# Altium schematic sheet farsterker.SchDoc
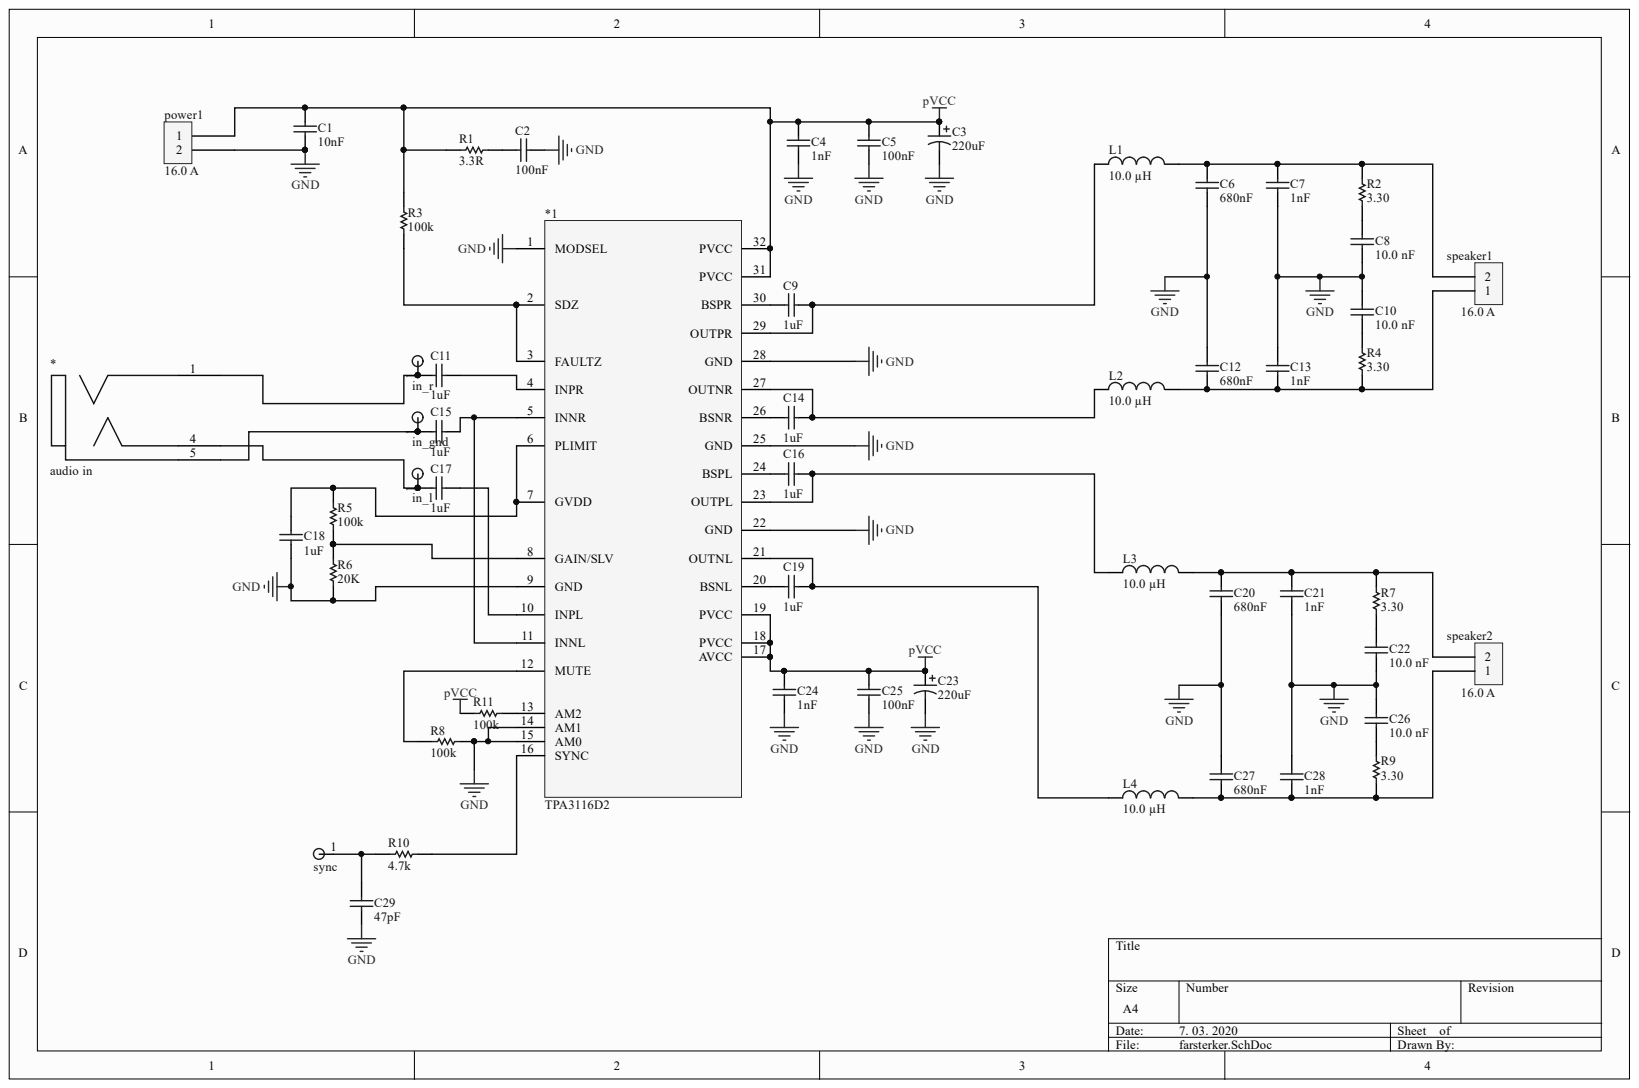
[diagram p0: farsterker.SchDoc]

## Parts
*  audio in  [PCB-329482-1]
*1  TPA3116D2  [PCB-1wxezy8eg5qk1v6v35wr-1]
C1  10nF  [CAPC2013X94X50NL20T25]
C2  100nF  [CAPC2013X94X50LL20T25]
C3  220uF  [EEUFC1V471]
C4  1nF  [CAPC2013X94X50NL20T25]
C5  100nF  [CAPC2013X140X50NL20T25]
C6  680nF  [CAPC2013X145X50NL20T25]
C7  1nF  [CAPC2013X94X50NL20T25]
C8  10.0 nF  [PCB-cftnmylkdkqegfcwasxi-1]
C9  1uF  [CAPC2013X94X50LL20T25]
C10  10.0 nF  [PCB-cftnmylkdkqegfcwasxi-1]
C11  1uF  [CAPC2013X94X50LL20T25]
C12  680nF  [CAPC2013X145X50NL20T25]
C13  1nF  [CAPC2013X94X50NL20T25]
C14  1uF  [CAPC2013X94X50LL20T25]
C15  1uF  [CAPC2013X94X50LL20T25]
C16  1uF  [CAPC2013X94X50LL20T25]
C17  1uF  [CAPC2013X94X50LL20T25]
C18  1uF  [CAPC2013X94X50LL20T25]
C19  1uF  [CAPC2013X94X50LL20T25]
C20  680nF  [CAPC2013X145X50NL20T25]
C21  1nF  [CAPC2013X94X50NL20T25]
C22  10.0 nF  [PCB-cftnmylkdkqegfcwasxi-1]
C23  220uF  [EEUFC1V471]
C24  1nF  [CAPC2013X94X50NL20T25]
C25  100nF  [CAPC2013X140X50NL20T25]
C26  10.0 nF  [PCB-cftnmylkdkqegfcwasxi-1]
C27  680nF  [CAPC2013X145X50NL20T25]
C28  1nF  [CAPC2013X94X50NL20T25]
C29  47pF  [CAPC2013X145X48LL20T23]
in_gnd  SYM-eb34223ee69c34f3-10  [PCB-a6m4wwq1w8vztsn322mx-1]  (value not drawn)
in_l  SYM-eb34223ee69c34f3-10  [PCB-a6m4wwq1w8vztsn322mx-1]  (value not drawn)
in_r  SYM-eb34223ee69c34f3-10  [PCB-a6m4wwq1w8vztsn322mx-1]  (value not drawn)
L1  10.0 µH  [PCB-qv8392ewdbk7uggmi3kr-1]
L2  10.0 µH  [PCB-qv8392ewdbk7uggmi3kr-1]
L3  10.0 µH  [PCB-qv8392ewdbk7uggmi3kr-1]
L4  10.0 µH  [PCB-qv8392ewdbk7uggmi3kr-1]
power1  16.0 A  [PCB-751604-1]
R1  3.3R  [RESC1608X55X30ML20T10]
R2  3.30  [PCB-61drmxuvl35l0xvdzxwi-1]
R3  100k  [RESC1608X55X30ML20T10]
R4  3.30  [PCB-61drmxuvl35l0xvdzxwi-1]
R5  100k  [RESC1608X55X30ML20T10]
R6  20K  [RESC1608X55X30ML20T10]
R7  3.30  [PCB-61drmxuvl35l0xvdzxwi-1]
R8  100k  [RESC1608X55X30ML20T10]
R9  3.30  [PCB-61drmxuvl35l0xvdzxwi-1]
R10  4.7k  [RESC1608X55X30ML20T10]
R11  100k  [RESC2013X60X35LL10T20]
speaker1  16.0 A  [PCB-751604-1]
speaker2  16.0 A  [PCB-751604-1]
sync  SYM-eb34223ee69c34f3-10  [PCB-a6m4wwq1w8vztsn322mx-1]  (value not drawn)

## Nets
GND: *1.1(MODSEL) *1.9(GND) *1.14(AM1) *1.15(AM0) *1.22(GND) *1.25(GND) *1.28(GND) C1.2 C2.2 C3.2 C4.1 C5.1 C6.1 C7.1 C8.1 C10.2 C12.2 C13.2 C18.1 C20.1 C21.1 C22.1 C23.2 C24.1 C25.1 C26.2 C27.2 C28.2 C29.1 power1.2 R6.2 R8.1
PVCC: *1.17(AVCC) *1.18(PVCC) *1.19(PVCC) *1.31(PVCC) *1.32(PVCC) C1.1 C3.1 C4.2 C5.2 C23.1 C24.2 C25.2 power1.1 R1.1 R3.2 R11.1
Net*1_2: *1.2(SDZ) *1.3(FAULTZ) R3.1
Net*1_4: *1.4(INPR) C11.2
Net*1_5: *1.5(INNR) *1.11(INNL) C15.2
Net*1_6: *1.6(PLIMIT) *1.7(GVDD) C18.2 R5.2
Net*1_8: *1.8(GAIN/SLV) R5.1 R6.1
Net*1_10: *1.10(INPL) C17.2
Net*1_12: *1.12(MUTE) R8.2
Net*1_13: *1.13(AM2) R11.2
Net*1_16: *1.16(SYNC) R10.2
Net*1_20: *1.20(BSNL) C19.1
Net*1_21: *1.21(OUTNL) C19.2 L4.1
Net*1_23: *1.23(OUTPL) C16.2 L3.1
Net*1_24: *1.24(BSPL) C16.1
Net*1_26: *1.26(BSNR) C14.1
Net*1_27: *1.27(OUTNR) C14.2 L2.1
Net*1_29: *1.29(OUTPR) C9.2 L1.1
Net*1_30: *1.30(BSPR) C9.1
Net*_1: *.1 C11.1 in_r.1
Net*_4: *.4 C17.1 in_l.1
Net*_5: *.5 C15.1 in_gnd.1
NetC2_1: C2.1 R1.2
NetC6_2: C6.2 C7.2 L1.2 R2.2 speaker1.2
NetC8_2: C8.2 R2.1
NetC10_1: C10.1 R4.2
NetC12_1: C12.1 C13.1 L2.2 R4.1 speaker1.1
NetC20_2: C20.2 C21.2 L3.2 R7.2 speaker2.2
NetC22_2: C22.2 R7.1
NetC26_1: C26.1 R9.2
NetC27_1: C27.1 C28.1 L4.2 R9.1 speaker2.1
NetC29_2: C29.2 R10.1 sync.1

## Library data of the parts
* = 35RASMT2BHNTRX: CONN JACK MONO 3.5MM SMD; Lead-Free Status: Lead Free; Component Kind: Standard; Library Name: Octopart library; Manufacturer Part Number: 35RASMT2BHNTRX; OctopartID: 3284fb872060ed43; Octopart Url: https://octopart.com/35rasmt2bhntrx-switchcraft-604034; RoHS: Compliant; Simulation: SIM-329482-1
*1 = TPA3116D2-1: footprint acording to data sheet with thermal pad; CustomComponentID: co6igaotrz3zpbi5ty22; Component Kind: Standard; Library Name: FavoritesLibrary
C1 = CMP-126154-2: CAP CER 39PF 100V 5% NP0 0805; Manufacturer Part Number: 08051A390JAT2A; Capacitance: 3.9e-11 F; Case/Package (SI): 2012; Dielectric Characteristic: C0G/NP0; Insulation Resistance: 1e+11 Ω; Lead-Free Status: Lead Free; Temperature Coefficient: 0.0 ppm/°C; Voltage Rating (DC): 100.0 V; Capacitance Tolerance: ±5%; Case/Package: 0805; Component Kind: Standard; Library Name: CommunityVault; Mounting Style: Surface Mount; Number of Pins: 2; OctopartID: dc1c17d34a2303db; Octopart Url: https://octopart.com/08051a390jat2a-avx+interconnect+%2F+elco-46510937; Packaging: Cut Tape (CT); REACH SVHC Compliance: No SVHC; RoHS: Compliant; Size-Length: 0.0020066 m; Size-Thickness: 0.0009398 m; Size-Width: 0.0012446 m
C2 C9 C11 C14-C19 = 08051A390JAT2A: CAP CER 39PF 100V 5% NP0 0805; Capacitance: 3.9e-11 F; Case/Package (SI): 2012; Dielectric Characteristic: C0G/NP0; Insulation Resistance: 1e+11 Ω; Lead-Free Status: Lead Free; Temperature Coefficient: 0.0 ppm/°C; Voltage Rating (DC): 100.0 V; Capacitance Tolerance: ±5%; Case/Package: 0805; Component Kind: Standard; Library Name: Octopart library; Manufacturer Part Number: 08051A390JAT2A; Mounting Style: Surface Mount; Number of Pins: 2; OctopartID: dc1c17d34a2303db; Octopart Url: https://octopart.com/08051a390jat2a-avx+interconnect+%2F+elco-46510937; Packaging: Cut Tape (CT); REACH SVHC Compliance: No SVHC; RoHS: Compliant; Size-Length: 0.0020066 m; Size-Thickness: 0.0009398 m; Size-Width: 0.0012446 m
C3 C23 = EEU-FC1V471: Cap Aluminum Lytic 470uF 35V 20% (10 X 20mm) Radial 5mm 1220mA 3000h 105Ø¢°C Automotive Bulk; Capacitance: 470.0 F; Dielectric Material: Aluminum Electrolytic; Lead-Free Status: Lead Free; Voltage Rating (DC): 35.0 V; Capacitance Tolerance: ±20%; Component Kind: Standard; Library Name: Octopart library; Lifecycle Status: Not Listed by Manufacturer; Manufacturer Part Number: EEU-FC1V471; Mounting Style: Through Hole; Number of Pins: 2; OctopartID: 1dacd6fc5c36c26b; Octopart URL: http://octopart.com/eeu-fc1v471-panasonic-39478524; Packaging: Bulk; REACH SVHC Compliance: No SVHC; RoHS: Compliant; Size-Diameter: 0.01 m; Size-Height: 0.02 m; Size-Length: 0.02 m
C4 C7 C13 C21 C24 C28 = CMP-19299402-2: CAP CER 1000PF 50V 1% NP0 0805; Case/Package (SI): 2012; Dielectric Characteristic: C0G/NP0; Dielectric Material: Ceramic Multilayer; Lead-Free Status: Lead Free; Voltage Rating (DC): 50.0 V; Capacitance: 1nF; Capacitance Tolerance: ±1%; Case/Package: 0805; Component Kind: Standard; Library Name: CommunityVault; Mounting Style: Surface Mount; Number of Pins: 2; OctopartID: 7684bf8caf2ca943; Octopart Url: https://octopart.com/08055a102fat2a-avx+interconnect+%2F+elco-195790; Packaging: Cut Tape (CT); REACH SVHC Compliance: No SVHC; RoHS: Compliant; Size-Height: 0.00094 m; Size-Length: 0.00201 m; Size-Width: 0.00125 m
C5 C25 = CMP-7535521-2: Multilayer Ceramic Capacitors MLCC - SMD/SMT 50volts 0.22uF 10% X7R 0805 SIZE; Manufacturer Part Number: 08055C224K4Z2A; Capacitance: 2.2e-07 F; Lead-Free Status: Lead Free; Voltage Rating (DC): 50.0 V; Capacitance Tolerance: ±10%; Case/Package: 0805; Component Kind: Standard; Dielectric Characteristic: X7R; Library Name: CommunityVault; OctopartID: 4b5d833d92a6949a; Octopart Url: https://octopart.com/08055c224k4z2a-avx+interconnect+%2F+elco-23788701; RoHS: Compliant
C6 C12 C20 C27 = CMP-124735-2: CAP CER 0.68UF 25V 5% X8L 0805; Dielectric Material: Ceramic; Lead-Free Status: Lead Free; Voltage Rating (DC): 25.0 V; Capacitance: 680nF; Capacitance Tolerance: ±5%; Case/Package: 0805; Component Kind: Standard; Dielectric Characteristic: X8L; Library Name: CommunityVault; Mounting Style: Surface Mount; Number of Pins: 2; OctopartID: 84034c15c9195bc4; Octopart Url: https://octopart.com/c0805c684j3nactu-kemet-18638972; Packaging: Cut Tape (CT); RoHS: Compliant
C8 C10 C22 C26 = CMP-13e0da60d94dcc27-1: Multilayer Ceramic Capacitor, GRM Series, 0.01 F, 1%, C0G / NP0, 50 V, 0805 [2012 Metric]; Manufacturer Part Number: GRM2195C1H103FA01D; Lead-Free Status: Lead Free; Voltage Rating (DC): 50.0 V; Capacitance: 10.0 nF; Capacitance Tolerance: ±1%; Component Kind: Standard; Dielectric Characteristic: C0G/NP0; Library Name: CommunityVault; Mounting Style: Surface Mount; OctopartID: 13e0da60d94dcc27; Octopart URL: http://octopart.com/grm2195c1h103fa01d-murata-9105355; Packaging: Cut Tape (CT); REACH SVHC Compliance: No SVHC; RoHS: Compliant; Size-Length: 2.00 mm; Size-Thickness: 850 µm; Size-Width: 1.25 mm
C29 = VJ0805Y104JXAAT: CAP CER 0.1UF 50V 5% X7R 0805; Capacitance: 1e-07 F; Case/Package (SI): 2012; Dielectric Material: Ceramic Multilayer; Voltage Rating (DC): 50.0 V; Capacitance Tolerance: ±5%; Case/Package: 0805; Component Kind: Standard; Dielectric Characteristic: X7R; Library Name: Octopart library; Manufacturer Part Number: VJ0805Y104JXAAT; Mounting Style: Surface Mount; Number of Pins: 2; OctopartID: c1860ad148051e41; Octopart Url: https://octopart.com/vj0805y104jxaat-vishay+vitramon-39693541; Packaging: Cut Tape (CT); RoHS: Compliant
in_gnd in_l in_r sync = 5006: Analogue Timer, Cam, Assembly Frame, 13, 3 S, 24 H Rohs Compliant: Yes; Component Kind: Standard; Library Name: Octopart library; Mounting Style: Through Hole, PC Board, PCB
L1-L4 = CMP-3e0af705fc29e1cd-1: Inductor Power Shielded Wirewound 10uH 20% 100KHz Ferrite 7.8A 14.88mOhm DCR T/R; Manufacturer Part Number: RLF12560T-100M7R5; Lead-Free Status: Lead Free; Resistance: 12.4 m?; Shielding: Yes; Component Kind: Standard; Inductance: 10.0 µH; Library Name: CommunityVault; Mounting Style: Surface Mount; Number of Pins: 2; OctopartID: 3e0af705fc29e1cd; Octopart URL: http://octopart.com/rlf12560t-100m7r5-tdk-386885; Packaging: Cut Tape (CT), Tape & Reel (TR); REACH SVHC Compliance: No SVHC; RoHS: Compliant; Size-Height: 6.00 mm; Size-Length: 12.5 mm; Size-Width: 12.8 mm
power1 speaker1 speaker2 = CMP-751604-7: Conn Terminal Block 2 POS 5.08mm Solder ST Thru-Hole 16A; Manufacturer Part Number: 1760510000; Lead-Free Status: Contains Lead; Number of Contacts: 2; Pin Pitch: 0.00508 m; Color: Black; Component Kind: Standard; Contact Material: Copper; Contact Plating: Tin; Current Rating: 16.0 A; Flammability Rating: UL 94 V-0; Housing Color: Black; Housing Material: Nylon; Library Name: CommunityVault; Mounting Style: PCB, Through Hole; Number of Positions: 2; Number of Rows: 1; OctopartID: 322efb20a324ede9; Octopart URL: http://octopart.com/1760510000-weidmuller-308332; RoHS: Compliant; Size-Height: 0.01 m; Size-Length: 0.01116 m; Size-Width: 0.008 m
R1 R3 R5 R6 R8 R10 = CMP-2823517-2: Res Thick Film 0603 0 Ohm Molded SMD Automotive Punched Paper T/R; Manufacturer Part Number: RK73Z1JTTD; Lead-Free Status: Lead Free; Resistance: 0.0 Ω; Case/Package: 0603; Component Kind: Standard; Library Name: CommunityVault; Mounting Style: Surface Mount; OctopartID: 9d21540b55d242e6; Octopart Url: https://octopart.com/rk73z1jttd-koa+speer-2157973; RoHS: Compliant; Size-Length: 0.0016 m; Size-Width: 0.0008 m
R2 R4 R7 R9 = CMP-777cbcf356dba8bb-1: Res Thick Film 3.2 x 1.6 mm 3.3 Ohm 1% 0.25W(1/4W) 200ppm/ C Molded SMD Paper T/R; Manufacturer Part Number: RC1206FR-073R3L; Case/Package (SI): 3216; Lead-Free Status: Lead Free; Power Rating: 250 mW; Voltage Rating (DC): 200 V; Case/Package: 1206; Component Kind: Standard; Library Name: CommunityVault; Mounting Style: Surface Mount; Number of Pins: 2; OctopartID: 777cbcf356dba8bb; Octopart URL: http://octopart.com/rc1206fr-073r3l-yageo-42271937; Packaging: Cut Tape (CT), Tape & Reel (TR); REACH SVHC Compliance: No SVHC; Resistance: 3.30; Resistance Tolerance: ±1%; RoHS: Compliant; Size-Height: 550 µm; Size-Length: 3.20 mm; Size-Width: 1.60 mm
R11 = RC0805JR-134K7L: YAGEO   RC0805JR-134K7L   THICK FILM RESISTOR, 4.7KOHM, 125mW, 5%; Lead-Free Status: Lead Free; Power Rating: 0.125 W; Resistance: 4700.0 Ω; Voltage Rating (DC): 150.0 V; Case/Package: 0805; Component Kind: Standard; Library Name: Octopart library; Manufacturer Part Number: RC0805JR-134K7L; Mounting Style: Surface Mount; Number of Pins: 2; OctopartID: c4a00d697db21b67; Octopart Url: https://octopart.com/rc0805jr-134k7l-yageo-12819572; Packaging: Cut Tape (CT); Resistance Tolerance: ±5%; RoHS: Compliant
